# Altium schematic sheet EStop.SchDoc(EStop)
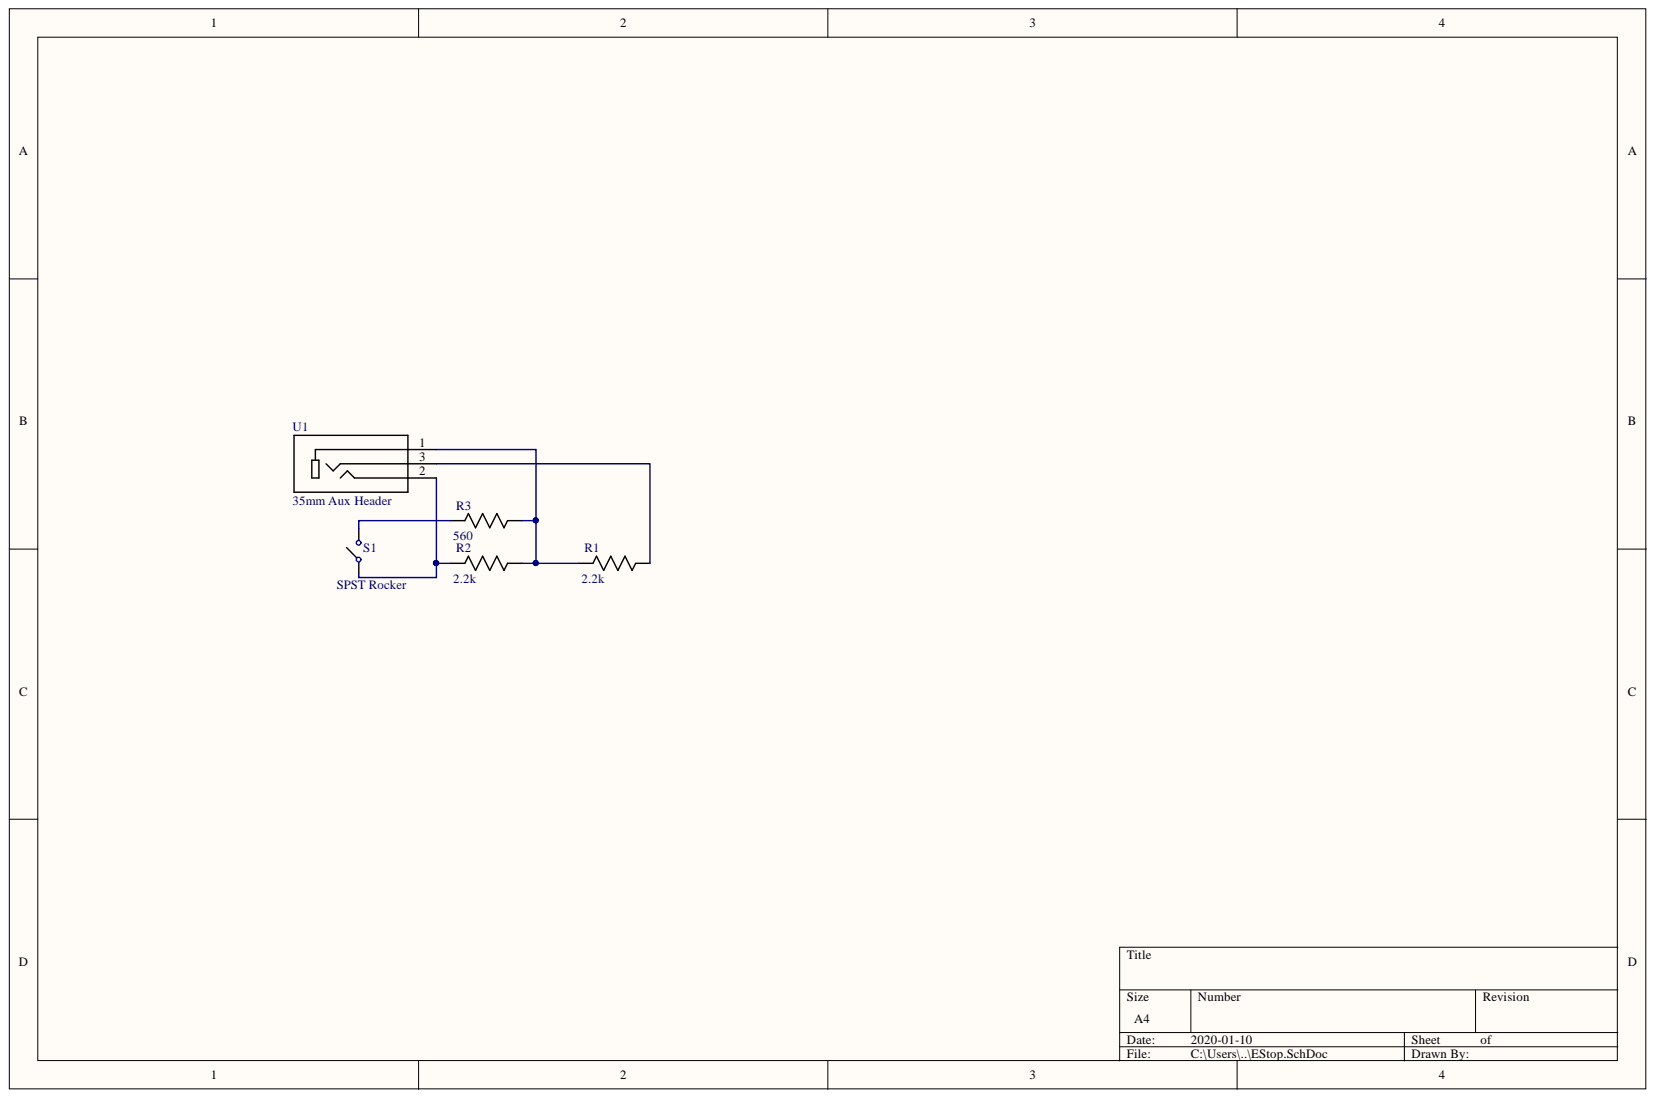
[diagram p0: EStop.SchDoc]

## Parts
R1  2.2k  [RESC2013X65N]
R2  2.2k  [RESC2013X65N]
R3  560  [RESC2013X65N]
S1  SPST Rocker  [SWITCH ROCKER SPST 10A 125V]
U1  35mm Aux Header  [35mm Aux Header]

## Nets
NetR1_1: R1.1 U1.3
NetR1_2: R1.2 R2.1 R3.1 U1.1
NetR2_2: R2.2 S1.0 U1.2
NetR3_2: R3.2 S1.1

## Library data of the parts
R1 R2 = RES 2.2k 0805: RES SMD 2.2K OHM 1% 1/8W 0805; Rating: 0.125W; MP: RC0805FR-072K2L; MF: Yageo; Value: 2.2k; Tolerance: 1%; Price: 0.15 CAD; Package: 0805; Library Name: AltiumLibraries.IntLib; Digikey Link: https://www.digikey.ca/product-detail/en/yageo/RC0805FR-072K2L/311-2.20KCRCT-ND/730623; Digikey: 311-2.20KCRCT-ND; Component Kind: Standard
R3 = RES 560 0805: RES 560 OHM 1% 1/8W 0805; Rating: 0.125W; MP: RMCF0805FT560R; MF: Stackpole Electronics Inc; Value: 560; Tolerance: 1%; Price: 0.15 CAD; Package: 0805; Library Name: AltiumLibraries.IntLib; Digikey Link: https://www.digikey.ca/product-detail/en/stackpole-electronics-inc/RMCF0805FT560R/RMCF0805FT560RCT-ND/2418431; Digikey: RMCF0805FT560RCT-ND; Component Kind: Standard
S1 = SWITCH ROCKER SPST 10A 125V: Rocker Switch SPST 10A (AC) 125V Panel Mount, Snap-In; MP: SRB22A2FBBNN; MF: ZF Electronics; Rating: 10A 125V; Price: 1.276 CAD; Library Name: AltiumLibraries.IntLib; Digikey Link: https://www.digikey.ca/product-detail/en/zf-electronics/SRB22A2FBBNN/CH755-ND/446021; Digikey: CH755-ND; Component Kind: Standard
U1 = 35mm Aux Header: Library Name: AltiumLibraries.IntLib; Component Kind: Standard
